# Altium schematic sheet SKC_PSU.SchDoc(SKC_PSU)
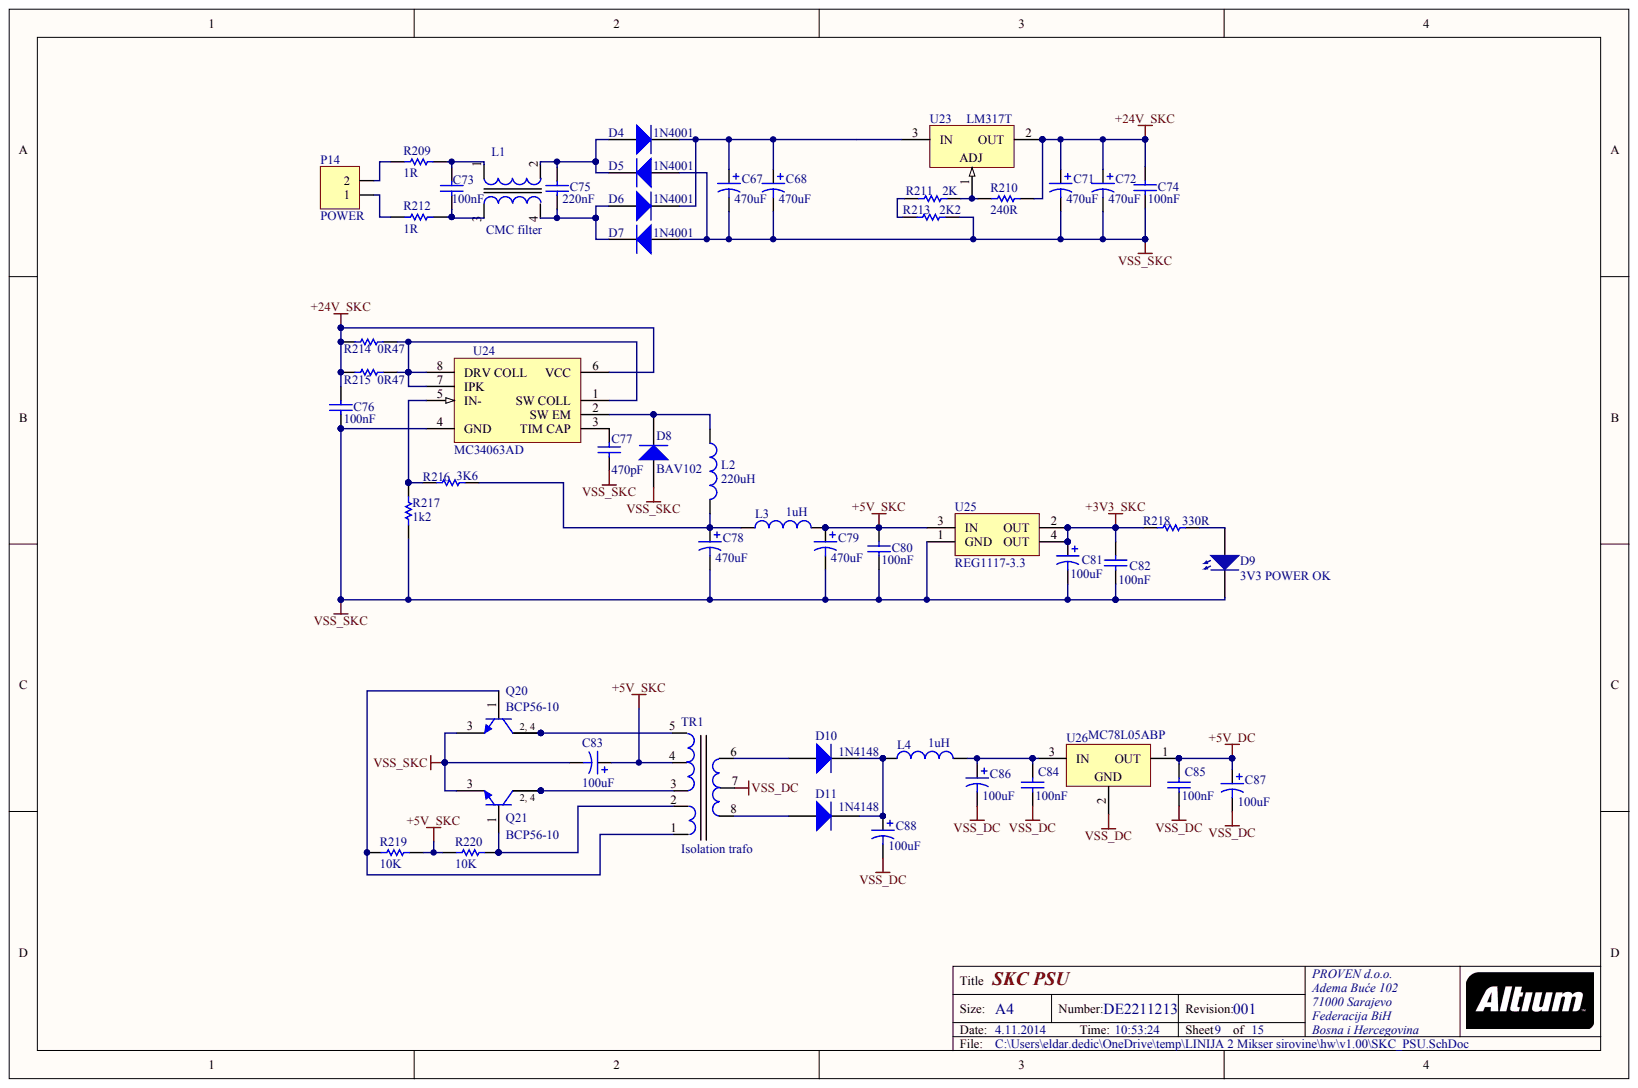
[diagram p0: SKC_PSU.SchDoc]

## Parts
C67  470uF
C68  470uF
C71  470uF
C72  470uF
C73  100nF
C74  value 100nF
C75  220nF
C76  value 100nF
C77  value 470pF
C78  470uF
C79  470uF
C80  value 100nF
C81  100uF
C82  value 100nF
C83  100uF
C84  value 100nF
C85  value 100nF
C86  100uF
C87  100uF
C88  100uF
D4  1N4001
D5  1N4001
D6  1N4001
D7  1N4001
D8  BAV102
D9  3V3 POWER OK
D10  1N4148
D11  1N4148
L1  CMC filter
L2  value 220uH
L3  value 1uH
L4  value 1uH
P14  POWER
Q20  BCP56-10
Q21  BCP56-10
R209  1R
R210  240R
R211  2K
R212  1R
R213  2K2
R214  0R47
R215  0R47
R216  3K6
R217  1k2
R218  330R
R219  10K
R220  10K
TR1  Isolation trafo
U23  LM317T
U24  MC34063AD
U25  REG1117-3.3
U26  MC78L05ABP

## Nets
+3V3_SKC: C81.1 C82.2 R218.1 U25.2(OUT) U25.4(OUT)
+5V_DC: C85.2 C87.1 U26.1
+5V_SKC: C79.1 C80.2 C83.1 L3.2 R219.1 R220.2 TR1.4 U25.3(IN)
+24V_SKC: C71.1 C72.1 C74.2 C76.2 R210.1 R214.1 R215.1 U23.2 U24.6(VCC)
VSS_DC: C84.1 C85.1 C86.2 C87.2 C88.2 TR1.7 U26.2
VSS_SKC: C67.2 C68.2 C71.2 C72.2 C74.1 C76.1 C77.1 C78.2 C79.2 C80.1 C81.2 C82.1 C83.2 D5.1 D7.1 D8.1 D9.1 Q20.3 Q21.3 R213.1 R217.1 U24.4(GND) U25.1(GND)
NetC67_1: C67.1 C68.1 D4.2 D6.2 U23.3
NetC73_1: C73.1 L1.3 R212.1
NetC73_2: C73.2 L1.1 R209.1
NetC75_1: C75.1 D6.1 D7.2 L1.4
NetC75_2: C75.2 D4.1 D5.2 L1.2
NetC77_2: C77.2 U24.3(TIM_CAP)
NetC78_1: C78.1 L2.2 L3.1 R216.2
NetC84_2: C84.2 C86.1 L4.2 U26.3
NetC88_1: C88.1 D10.2 D11.2 L4.1
NetD8_2: D8.2 L2.1 U24.2(SW_EM)
NetD9_2: D9.2 R218.2
NetD10_1: D10.1 TR1.6
NetD11_1: D11.1 TR1.8
NetP14_1: P14.1 R212.2
NetP14_2: P14.2 R209.2
NetQ20_1: Q20.1 R219.2 TR1.1
NetQ20_2: Q20.2 Q20.4 TR1.5
NetQ21_1: Q21.1 R220.1 TR1.2
NetQ21_2: Q21.2 Q21.4 TR1.3
NetR210_2: R210.2 R211.2 U23.1
NetR211_1: R211.1 R213.2
NetR214_2: R214.2 R215.2 U24.1(SW_COLL) U24.7(IPK) U24.8(DRV_COLL)
NetR216_1: R216.1 R217.2 U24.5(IN-)

## Library data of the parts
C67 C68 C71 C72 C78 C79 C81 C83 C86-C88 = (no symbol name): Note: Refer to Manufacturer's Data Sheets for other lead formations; DrillSize: Nominal, Rounded To The Nearest 0.1mm; Published: 19-Mar-2004; DatasheetDocument: 20-Feb-2001; ComponentLink1Description: Manufacturer Link; ComponentLink1URL: http://www.vishay.com/; ComponentLink2Description: Datasheet; ComponentLink2URL: http://www.vishay.com/docs/25014/eka.pdf; Publisher: Altium Limited
  C67 C68 C71 C72 C78 C79: PackageReference: CAPPR5-10X16; Value: 470uF
  C81 C83 C86-C88: PackageReference: CAPPR2-5X11; Value: 100uF
C73 C75 = (no symbol name): Value: 68nF; Published: 17-Dec-2003; LatestRevisionDate: 19-Mar-2004; LatestRevisionNote: PCB Footprint - Drill hole diameter reduced.; DatasheetDocument: 2-Jan-2002; PackageDocument: 2-Jan-2002; PackageReference: VP60-3.6; ComponentLink1Description: Manufacturer Link; ComponentLink1URL: http://www.vishay.com/; Publisher: Altium Limited
C77 = (no symbol name): Note: Footprint corresponds to dimensions for bulk cassette packaging. This case size has tightened tolerances.; Published: 17-Jul-2002; LatestRevisionDate: 19-Mar-2004; LatestRevisionNote: Silkscreen size reduced.; DatasheetDocument: Mar-2001; PackageDocument: Mar-2001; PackageReference: 0805; Code_JEITA: 0805; Code_IPC: 2012[0805]; ComponentLink1Description: Manufacturer Link; ComponentLink1URL: http://www.kemet.com/; ComponentLink2Description: Datasheet; ComponentLink2URL: http://www.kemet.com/kemet/web/homepage/kechome.nsf/vapubfilesname/F3102TA.pdf/$file/F3102TA.pdf; Publisher: Altium Limited
L2-L4 = (no symbol name): PCB_Layout: Complies with manufacturer's recommendation.; Published: 26-Sep-2008; DatasheetVersion: Oct-2007; PackageVersion: Oct-2007; PackageReference: PCMC063T; PackageDescription: SM Power Choke Coil; 2 Leads; Body 7.3 x 6.6 x 3.0 mm (LxWxH); ComponentLink1Description: Manufacturer Link; ComponentLink1URL: http://www.susumu.co.jp/; ComponentLink2Description: Datasheet; ComponentLink2URL: http://www.susumu.co.jp/english/pdf/products-j07-01.pdf; Publisher: Altium Limited
R210 R211 R213 R216-R220 = (no symbol name): Note: If flow soldering, adjust pad width of PCB Footprint to 0.7 - 0.8 times the width of the resistor.; Published: 17-Dec-2003; LatestRevisionDate: 19-Mar-2004; LatestRevisionNote: Silkscreen size reduced.; PackageDocument: Mar-2003; ComponentLink1Description: Manufacturer Link; ComponentLink1URL: http://www.panasonic.com/industrial/components/resistive_products.htm; ComponentLink2Description: Datasheet; Publisher: Altium Limited
  R210 R211 R213 R216 R217 R219 R220: PackageReference: ERA-0805; Code_IPC: 2012 [0805]; ComponentLink2URL: http://www.panasonic.com/industrial/components/pdf/AOF0000CE1.pdf
  R218: PackageReference: ERA-0603; Code_IPC: 1608 [0603]; ComponentLink2URL: http://www.panasonic.com/industrial/components/pdf/AOA0000CE8.pdf
U23 = (no symbol name): Note: Heatsink surface connected to pin 2.; Published: 17-Jul-2002; DatasheetVersion: 1988; PackageReference: 221A-04; PackageDescription: TO, Thru-Hole, Vertical, Heatsink Mounted; 3 In-Line Leads; Pitch 2.54 mm; Code_JEDEC: TO-262-AA; ComponentLink1Description: Manufacturer Link; ComponentLink1URL: http://www.onsemi.com/site/products/taxonomy/0,4438,503,00.html; ComponentLink2Description: Datasheet; ComponentLink2URL: http://www.onsemi.com/pub/Collateral/LM317-D.PDF; Publisher: Altium Limited
C74 C76 C80 C82 C84 C85 D4-D11 L1 P14 Q20 Q21 R209 R212 R214 R215 TR1 U24-U26 = (no symbol name)
  C74 C76 C80 C82 C84 C85: Published: 17-Jul-2002; LatestRevisionDate: 19-Mar-2004; LatestRevisionNote: Silkscreen size reduced.; DatasheetDocument: Mar-2001; PackageDocument: Mar-2001; PackageReference: 1206; Code_JEITA: 1206; Code_IPC: 3216 [1206]; ComponentLink1Description: Manufacturer Link; ComponentLink1URL: http://www.kemet.com/; ComponentLink2Description: Datasheet; ComponentLink2URL: http://www.kemet.com/kemet/web/homepage/kechome.nsf/vapubfilesname/F3102TA.pdf/$file/F3102TA.pdf
  D4-D7: Published: 8-Jun-2000; LatestRevisionDate: 17-Jul-2002; LatestRevisionNote: Re-released for DXP Platform.; DatasheetVersion: 1998; PackageVersion: Aug-1999; PackageReference: DO-41; PackageDescription: Diode, Axial; Body 5.2 x 2.7 mm (LxDia. max), Lead Dia. 0.86 mm (max); Code_JEDEC: DO-204-AL; ComponentLink1Description: Manufacturer Link; ComponentLink1URL: http://www.fairchildsemi.com/; ComponentLink2Description: Datasheet; ComponentLink2URL: http://www.fairchildsemi.com/ds/1N%2F1N4001.pdf
  D8: Published: 17-Sep-2003; LatestRevisionDate: 19-Mar-2004; LatestRevisionNote: Silkscreen size reduced.; DatasheetVersion: 17-Sep-1996; PackageVersion: Jan-2002; PackageReference: SOD80C; PackageDescription: Metal Electrode Face (MELF) Diode; Body 3.5 x 1.6 mm (LxDia); ComponentLink1Description: Manufacturer Link; ComponentLink1URL: http://www.semiconductors.philips.com/; ComponentLink2Description: Datasheet; ComponentLink2URL: http://www.semiconductors.philips.com/acrobat/datasheets/BAV100_2.pdf
  D10 D11: Published: 8-Jun-2000; LatestRevisionDate: 17-Jul-2002; LatestRevisionNote: Re-released for DXP Platform.; DatasheetVersion: 1997; PackageReference: DO-35; PackageDescription: Diode, Axial; Body 3.8 x 1.9 mm (LxDia. max), Lead Dia. 0.53 mm (max); Code_JEDEC: DO-204-AH; ComponentLink1Description: Manufacturer Link; ComponentLink1URL: http://www.fairchildsemi.com/; ComponentLink2Description: Datasheet; ComponentLink2URL: http://www.fairchildsemi.com/ds/1N%2F1N4148.pdf
  Q20 Q21: Published: 17-Sep-2003; LatestRevisionDate: 12-Dec-2006; LatestRevisionNote: IPC-7351 Footprint Added.; DatasheetVersion: 10-Oct-2001; PackageVersion: Aug-2001; PackageReference: SOT223; PackageDescription: 4-Pin SOT-223 Package 2.3 mm Pitch, 7 mm Lead Span; ComponentLink1Description: Manufacturer Link; ComponentLink1URL: http://www.semiconductors.philips.com/; ComponentLink2Description: Datasheet; ComponentLink2URL: http://www.semiconductors.philips.com/acrobat/datasheets/BC639_BCP56_BCX56_7.pdf
  R209 R212: Published: 17-Dec-2003; PackageReference: R6.35x2.3; ComponentLink1Description: Manufacturer Link; ComponentLink1URL: http://www.panasonic.com/industrial/components/resistive_products.htm; ComponentLink2Description: Datasheet; ComponentLink2URL: http://www.panasonic.com/industrial/components/pdf/AOA0000CE18.pdf
  R214 R215: Published: 17-Dec-2003; PackageReference: RD6.35x2.3; ComponentLink1Description: Manufacturer Link; ComponentLink1URL: http://www.panasonic.com/industrial/components/resistive_products.htm; ComponentLink2Description: Datasheet; ComponentLink2URL: http://www.panasonic.com/industrial/components/pdf/AOA0000CE12.pdf
  U24: Published: 15-Apr-2002; LatestRevisionDate: 13-Apr-2006; LatestRevisionNote: IPC-7351 Footprint Added.; DatasheetVersion: 1996; PackageVersion: 1998; PackageReference: 751-05; PackageDescription: 8-Pin Small Outline Integrated Circuit 1.27 mm Pitch; Code_JEDEC: MS-012-AA; Code_IPC: SOIC127P600-8; ComponentLink1Description: Manufacturer Link; ComponentLink1URL: http://www.onsemi.com/site/products/taxonomy/0,4438,503,00.html; ComponentLink2Description: Datasheet; ComponentLink2URL: http://www.onsemi.com/pub/Collateral/MC34063A-D.PDF
  U25: Published: 8-Jun-2000; LatestRevisionDate: 17-Jul-2002; LatestRevisionNote: Re-released for DXP Platform.; DatasheetVersion: Sep-1997; PackageVersion: revC; PackageReference: ZZ311; PackageDescription: SOT-223/SC-73; 4 Leads; Body 6.7 x 7.3 mm, inc. leads (LxW max); ComponentLink1Description: Manufacturer Link; ComponentLink1URL: http://www.ti.com/; ComponentLink2Description: Datasheet; ComponentLink2URL: http://www-s.ti.com/sc/ds/REG1117.pdf
  U26: Published: 17-Jul-2002; DatasheetVersion: 1988; PackageVersion: 1996; PackageReference: 29-04; PackageDescription: TO, Flat Index; 3 In-Line, Axial Leads; Body Dia. 4.8mm; Leads 0.48 x 0.5 mm (max); ComponentLink1Description: Manufacturer Link; ComponentLink1URL: http://www.onsemi.com/site/products/taxonomy/0,4438,503,00.html; ComponentLink2Description: Datasheet; ComponentLink2URL: http://www.onsemi.com/pub/Collateral/MC78L00A-D.PDF
  C74 C76 C80 C82 C84 C85 D4-D8 D10 D11 Q20 Q21 R209 R212 R214 R215 U24-U26: Publisher: Altium Limited
